# Altium schematic sheet 07 - BANK 0, BANK13, JTAG.SchDoc("07 - BANK 0, BANK13, JTAG")
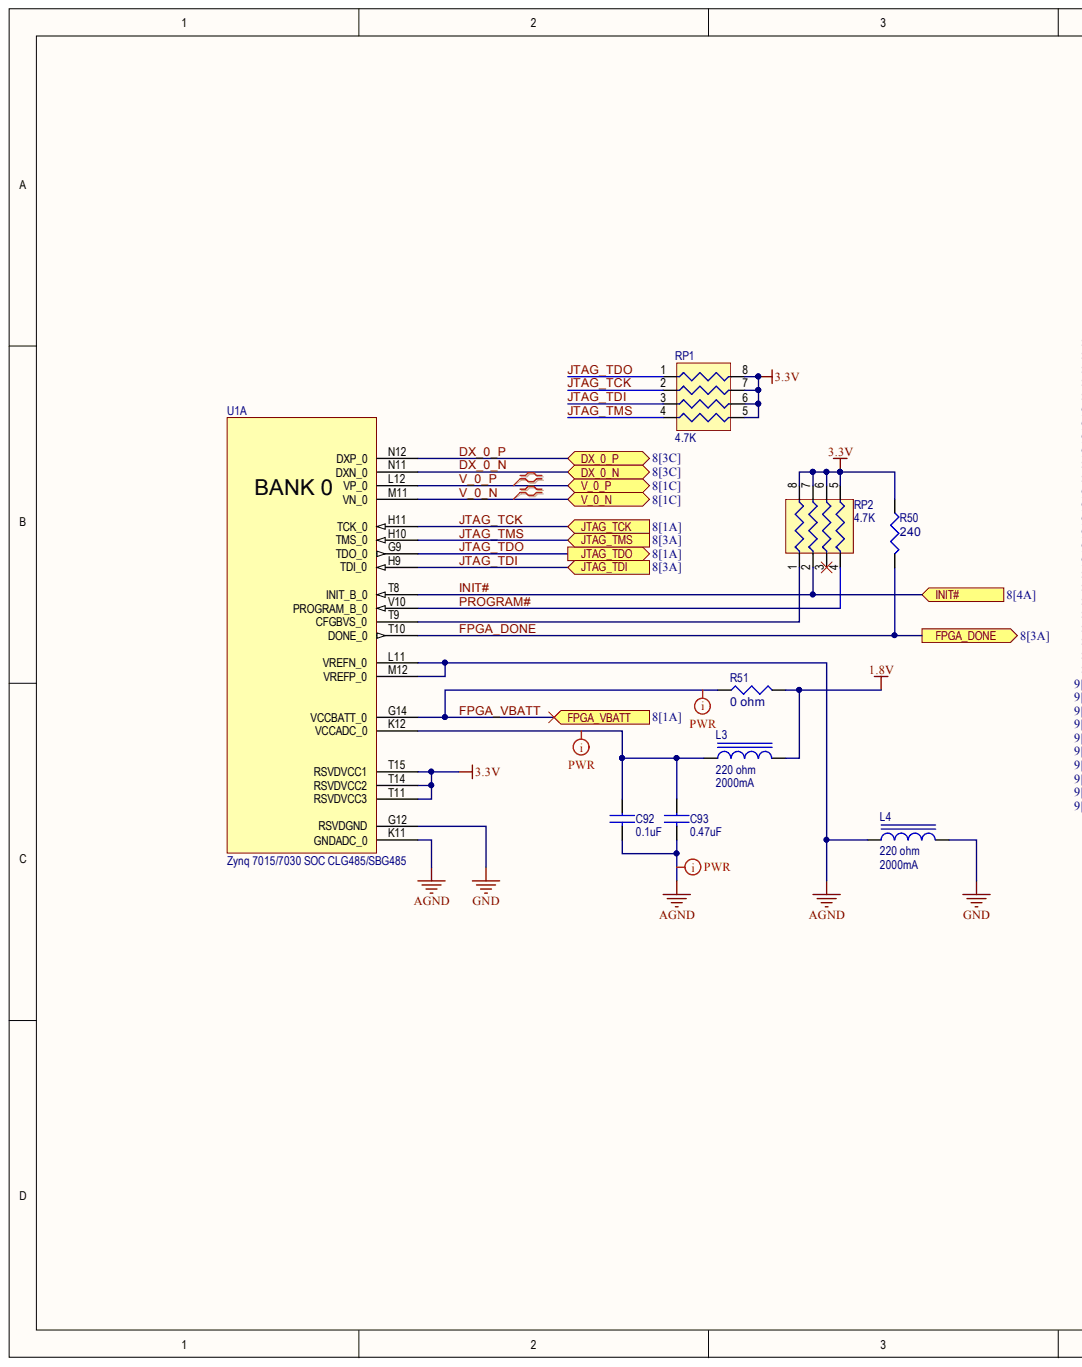
[diagram p0: 07 - BANK 0, BANK13, JTAG.SchDoc, left]
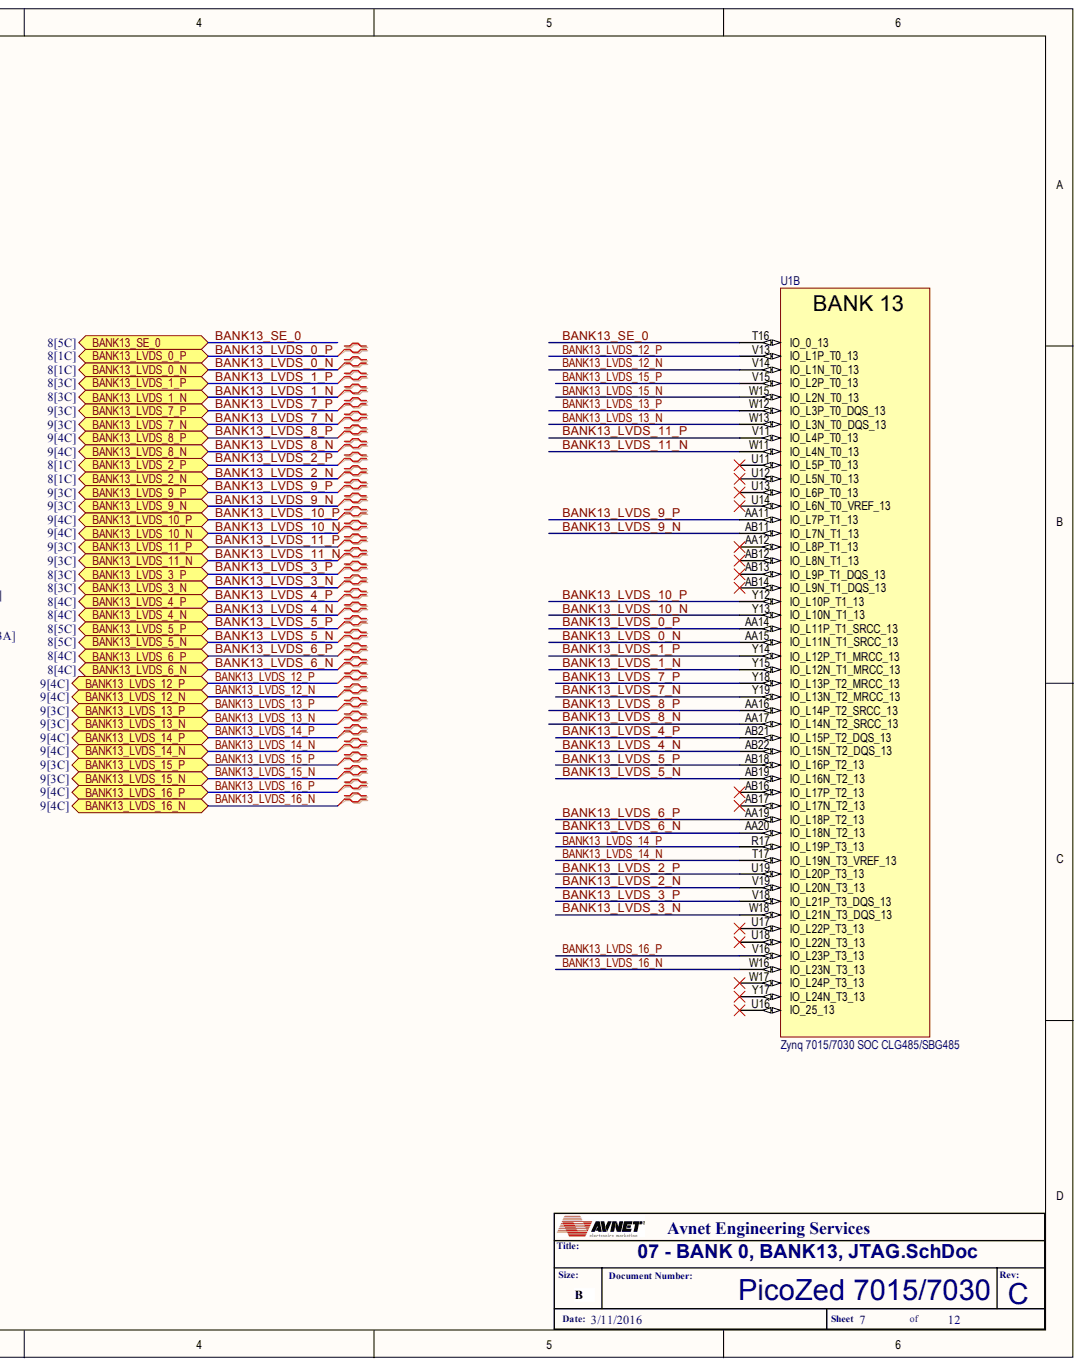
[diagram p1: 07 - BANK 0, BANK13, JTAG.SchDoc, right]

## Parts
C92  value 0.1uF  [C0201]  (hidden comment: PC522)
C93  value 0.47uF  [C0402]  (hidden comment: PC475)
L3  value 220 ohm  Max I: 2000mA  [L0805]  (hidden comment: PF009)
L4  value 220 ohm  Max I: 2000mA  [L0805]  (hidden comment: PF009)
R50  240  [R0402]
R51  0 ohm  [R0402]
RP1  value 4.7K  [RPACK4-LCC]  (hidden comment: PR010)
RP2  value 4.7K  [RPACK4-LCC]  (hidden comment: PR010)
U1  Zynq 7015/7030 SOC CLG485/SBG485  [BGA81C80P22X22_1900X1900X244]  (parts A,B)

## Ports
BANK13_LVDS_0_N, BANK13_LVDS_0_P, BANK13_LVDS_1_N, BANK13_LVDS_1_P, BANK13_LVDS_2_N, BANK13_LVDS_2_P, BANK13_LVDS_3_N, BANK13_LVDS_3_P, BANK13_LVDS_4_N, BANK13_LVDS_4_P, BANK13_LVDS_5_N, BANK13_LVDS_5_P, BANK13_LVDS_6_N, BANK13_LVDS_6_P, BANK13_LVDS_7_N, BANK13_LVDS_7_P, BANK13_LVDS_8_N, BANK13_LVDS_8_P, BANK13_LVDS_9_N, BANK13_LVDS_9_P, BANK13_LVDS_10_N, BANK13_LVDS_10_P, BANK13_LVDS_11_N, BANK13_LVDS_11_P, BANK13_LVDS_12_N, BANK13_LVDS_12_P, BANK13_LVDS_13_N, BANK13_LVDS_13_P, BANK13_LVDS_14_N, BANK13_LVDS_14_P, BANK13_LVDS_15_N, BANK13_LVDS_15_P, BANK13_LVDS_16_N, BANK13_LVDS_16_P, BANK13_SE_0, DX_0_N, DX_0_P, FPGA_DONE, FPGA_VBATT, INIT#, JTAG_TCK, JTAG_TDI, JTAG_TDO, JTAG_TMS, V_0_N, V_0_P

## Nets
1.8V: L3.2 R51.2
3.3V: R50.1 RP1.5 RP1.6 RP1.7 RP1.8 RP2.5 RP2.6 RP2.7 RP2.8 U1.T11(RSVDVCC3) U1.T14(RSVDVCC2) U1.T15(RSVDVCC1)
AGND: C92.2 C93.2 L4.1 U1.K11(GNDADC_0) U1.L11(VREFN_0) U1.M12(VREFP_0)
GND: L4.2 U1.G12(RSVDGND)
BANK13_LVDS_0_N: U1.AA15(IO_L11N_T1_SRCC_13) ; port BANK13_LVDS_0_N
BANK13_LVDS_0_P: U1.AA14(IO_L11P_T1_SRCC_13) ; port BANK13_LVDS_0_P
BANK13_LVDS_1_N: U1.Y15(IO_L12N_T1_MRCC_13) ; port BANK13_LVDS_1_N
BANK13_LVDS_1_P: U1.Y14(IO_L12P_T1_MRCC_13) ; port BANK13_LVDS_1_P
BANK13_LVDS_2_N: U1.V19(IO_L20N_T3_13) ; port BANK13_LVDS_2_N
BANK13_LVDS_2_P: U1.U19(IO_L20P_T3_13) ; port BANK13_LVDS_2_P
BANK13_LVDS_3_N: U1.W18(IO_L21N_T3_DQS_13) ; port BANK13_LVDS_3_N
BANK13_LVDS_3_P: U1.V18(IO_L21P_T3_DQS_13) ; port BANK13_LVDS_3_P
BANK13_LVDS_4_N: U1.AB22(IO_L15N_T2_DQS_13) ; port BANK13_LVDS_4_N
BANK13_LVDS_4_P: U1.AB21(IO_L15P_T2_DQS_13) ; port BANK13_LVDS_4_P
BANK13_LVDS_5_N: U1.AB19(IO_L16N_T2_13) ; port BANK13_LVDS_5_N
BANK13_LVDS_5_P: U1.AB18(IO_L16P_T2_13) ; port BANK13_LVDS_5_P
BANK13_LVDS_6_N: U1.AA20(IO_L18N_T2_13) ; port BANK13_LVDS_6_N
BANK13_LVDS_6_P: U1.AA19(IO_L18P_T2_13) ; port BANK13_LVDS_6_P
BANK13_LVDS_7_N: U1.Y19(IO_L13N_T2_MRCC_13) ; port BANK13_LVDS_7_N
BANK13_LVDS_7_P: U1.Y18(IO_L13P_T2_MRCC_13) ; port BANK13_LVDS_7_P
BANK13_LVDS_8_N: U1.AA17(IO_L14N_T2_SRCC_13) ; port BANK13_LVDS_8_N
BANK13_LVDS_8_P: U1.AA16(IO_L14P_T2_SRCC_13) ; port BANK13_LVDS_8_P
BANK13_LVDS_9_N: U1.AB11(IO_L7N_T1_13) ; port BANK13_LVDS_9_N
BANK13_LVDS_9_P: U1.AA11(IO_L7P_T1_13) ; port BANK13_LVDS_9_P
BANK13_LVDS_10_N: U1.Y13(IO_L10N_T1_13) ; port BANK13_LVDS_10_N
BANK13_LVDS_10_P: U1.Y12(IO_L10P_T1_13) ; port BANK13_LVDS_10_P
BANK13_LVDS_11_N: U1.W11(IO_L4N_T0_13) ; port BANK13_LVDS_11_N
BANK13_LVDS_11_P: U1.V11(IO_L4P_T0_13) ; port BANK13_LVDS_11_P
BANK13_LVDS_12_N: U1.V14(IO_L1N_T0_13) ; port BANK13_LVDS_12_N
BANK13_LVDS_12_P: U1.V13(IO_L1P_T0_13) ; port BANK13_LVDS_12_P
BANK13_LVDS_13_N: U1.W13(IO_L3N_T0_DQS_13) ; port BANK13_LVDS_13_N
BANK13_LVDS_13_P: U1.W12(IO_L3P_T0_DQS_13) ; port BANK13_LVDS_13_P
BANK13_LVDS_14_N: U1.T17(IO_L19N_T3_VREF_13) ; port BANK13_LVDS_14_N
BANK13_LVDS_14_P: U1.R17(IO_L19P_T3_13) ; port BANK13_LVDS_14_P
BANK13_LVDS_15_N: U1.W15(IO_L2N_T0_13) ; port BANK13_LVDS_15_N
BANK13_LVDS_15_P: U1.V15(IO_L2P_T0_13) ; port BANK13_LVDS_15_P
BANK13_LVDS_16_N: U1.W16(IO_L23N_T3_13) ; port BANK13_LVDS_16_N
BANK13_LVDS_16_P: U1.V16(IO_L23P_T3_13) ; port BANK13_LVDS_16_P
BANK13_SE_0: U1.T16(IO_0_13) ; port BANK13_SE_0
DX_0_N: U1.N11(DXN_0) ; port DX_0_N
DX_0_P: U1.N12(DXP_0) ; port DX_0_P
FPGA_DONE: R50.2 U1.T10(DONE_0) ; port FPGA_DONE
FPGA_VBATT: R51.1 U1.G14(VCCBATT_0) ; port FPGA_VBATT
INIT#: RP2.2 U1.T8(INIT_B_0) ; port INIT#
JTAG_TCK: RP1.2 U1.H11(TCK_0) ; port JTAG_TCK
JTAG_TDI: RP1.3 U1.H9(TDI_0) ; port JTAG_TDI
JTAG_TDO: RP1.1 U1.G9(TDO_0) ; port JTAG_TDO
JTAG_TMS: RP1.4 U1.H10(TMS_0) ; port JTAG_TMS
PROGRAM#: RP2.4 U1.V10(PROGRAM_B_0)
V_0_N: U1.M11(VN_0) ; port V_0_N
V_0_P: U1.L12(VP_0) ; port V_0_P
NetC92_1: C92.1 C93.1 L3.1 U1.K12(VCCADC_0)
NetRP2_1: RP2.1 U1.T9(CFGBVS_0)

Not connected: RP2 3; U1 AA12(IO_L8P_T1_13) AB12(IO_L8N_T1_13) AB13(IO_L9P_T1_DQS_13) AB14(IO_L9N_T1_DQS_13) AB16(IO_L17P_T2_13) AB17(IO_L17N_T2_13) U11(IO_L5P_T0_13) U12(IO_L5N_T0_13) U13(IO_L6P_T0_13) U14(IO_L6N_T0_VREF_13) U16(IO_25_13) U17(IO_L22P_T3_13) U18(IO_L22N_T3_13) W17(IO_L24P_T3_13) Y17(IO_L24N_T3_13)

## Library data of the parts
C92 = AVPC-0000522: CAP SM 0.1uF 16V 10% X5R C0201; PartCode: PC; Database Table Name: Passive-Cap$; MFG: TDK; MFGPN: C0603X5R1C104K030BC; Temp: I; AsyTxt: PC522; CLASSNAME: U11_BYP; Component Kind: Standard; ComponentLink1Description: Datasheet; Device: CAP; Dielectric: X5R; MFG Code: TDK; PkgType: SM; RoHS: Yes; Status: Preliminary; Tol: 10%; Volt: 16V; Library Name: Avnet_DBlib.DbLib
C93 = AVPC-0000475: CAP SM 0.47uF 6.3V 10% X5R C0402; PartCode: PC; AVLNo: AVPC-0000529; Database Table Name: Passive-Cap$; MFG: Taiyo Yuden; MFG Code: TAI; MFGPN: JMK105BJ474KV-F; Temp: I; AsyTxt: PC475; Component Kind: Standard; ComponentLink1Description: Datasheet; ComponentLink2Description: MFR Website; Device: CAP; Dielectric: X5R; ESR: CAP; PkgType: SM; RoHS: Yes; Status: Preliminary; Tol: 10%; Volt: 6.3V; ComponentLink1URL: C:\AVDBLIB\DATASHEETS\; Library Name: Avnet_DBlib.DbLib
L3 L4 = AVPF-0000009: FB SM 220 ohm@100MHz 2000mA 0.25 0.045 ohm@DC L0805; PartCode: PF; Database Table Name: Passive-Ferrite Bead$; MFG: Murata; MFG Code: MUR; MFGPN: BLM21PG221SN1D; Temp: C; Test Freq: 100MHz; Tol: 0.25; AsyTxt: PF009; AVLNo: AVPF-0000009; Component Kind: Standard; ComponentLink1Description: Datasheet; DCR: 0.045 ohm; Device: FB; PkgType: SM; RoHS: Yes; Status: Preliminary; ComponentLink1URL: C:\AVDBLIB\DATASHEETS\L0110S0100BLM21P.pdf; Library Name: Avnet_DBlib.DbLib
R50 = AVPR-0000511: RES SM 240 62mW 0.01 R0402; Alt1MFR: NIC; PartCode: PR; Alt1PN: NRC04F2400TRF; AsyTxt: PR511; Database Table Name: Passive-Res$; MFG: Vishay; MFG Code: DAL; MFGPN: CRCW0402240RFKED; Power: 0; Temp: I; Tol: 0.01; Availability: 1M-AV; AVLNo: AVPR-0000511; Component Kind: Standard; ComponentLink1Description: Datasheet; Cost: .01; Device: RES; PkgType: SM; Received: 0; RoHS: Yes; Size: 1.9; Status: Preliminary; Value: 240; Watt: 62mW; ComponentLink1URL: C:\AVDBLIB\DATASHEETS\Dale_CRCW.pd; Library Name: Avnet_DBlib.DbLib
R51 = AVPR-0000027: RES SM 0 ohm 62mW J R0402; PartCode: PR; AsyTxt: PR027; Database Table Name: Passive-Res$; MFG: Vishay; MFG Code: DAL; MFGPN: CRCW04020000Z0ED; Temp: I; AVLNo: AVPR-0000027; Component Kind: Standard; ComponentLink1Description: Datasheet; Device: RES; PkgType: SM; RoHS: Yes; Status: Preliminary; Value: 0 ohm; Watt: 62mW; ComponentLink1URL: C:\AVDBLIB\DATASHEETS\Dale_CRCW.pd; Library Name: Avnet_DBlib.DbLib
RP1 RP2 = AVPR-0000010: RPACK SM 4.7K 62mW 0.05 RPACK4-LCC; PartCode: PR; Database Table Name: Passive-Res$; MFG: Bourns; MFG Code: BNS; MFGPN: CAT16-472J4LF; Temp: I; Tol: 0.05; AsyTxt: PR010; AVLNo: AVPR-0000010; Component Kind: Standard; ComponentLink1Description: Datasheet; Device: RPACK; PkgType: SM; RoHS: Yes; Status: Preliminary; Watt: 62mW; ComponentLink1URL: C:\AVDBLIB\DATASHEETS\CAT_CAY.pdf; Library Name: Avnet_DBlib.DbLib
U1 = Zynq 7015/7030 SOC SBG485: MFG: Xilinx; MFGPN: XC7Z030-1SBG485-C; Temp: C; CLASSNAME: BGA; Component Kind: Standard; Description: Zynq 7015/7030 SOC SBG485; Library Name: PicoZed+_Rev_A.SCHLIB
